# Altium schematic sheet USB_INPUT.SchDoc
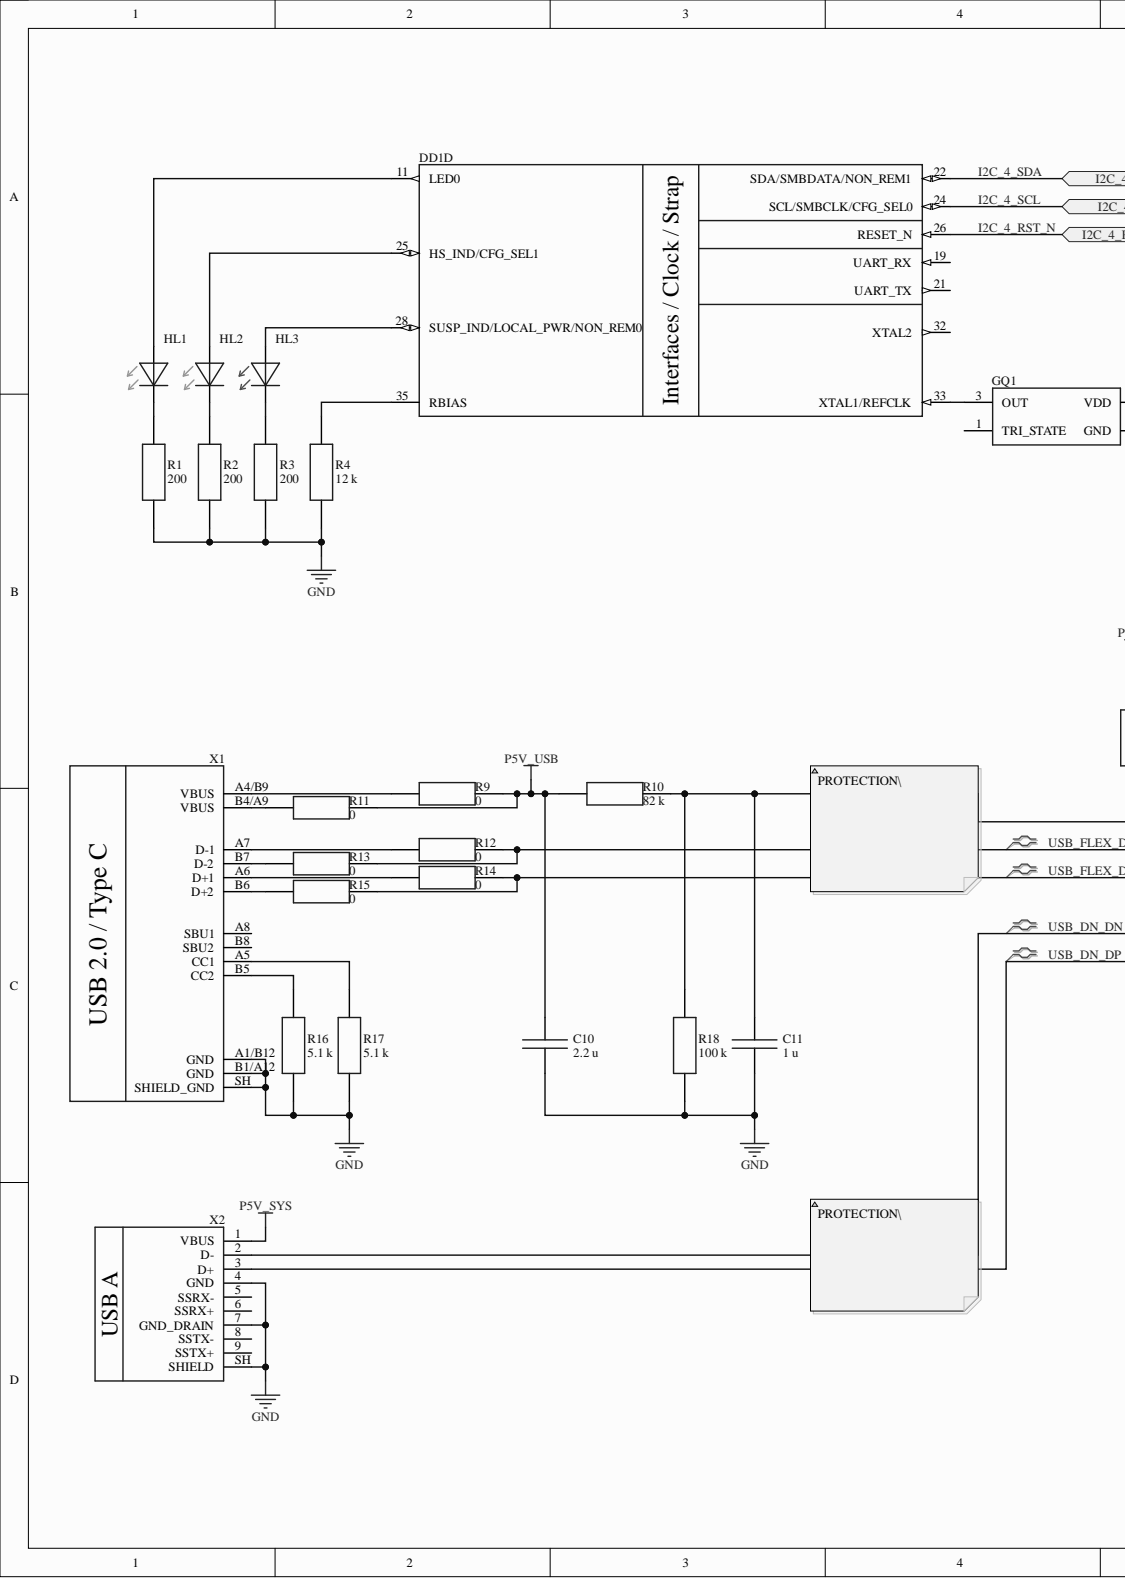
[diagram p0: USB_INPUT.SchDoc, left]
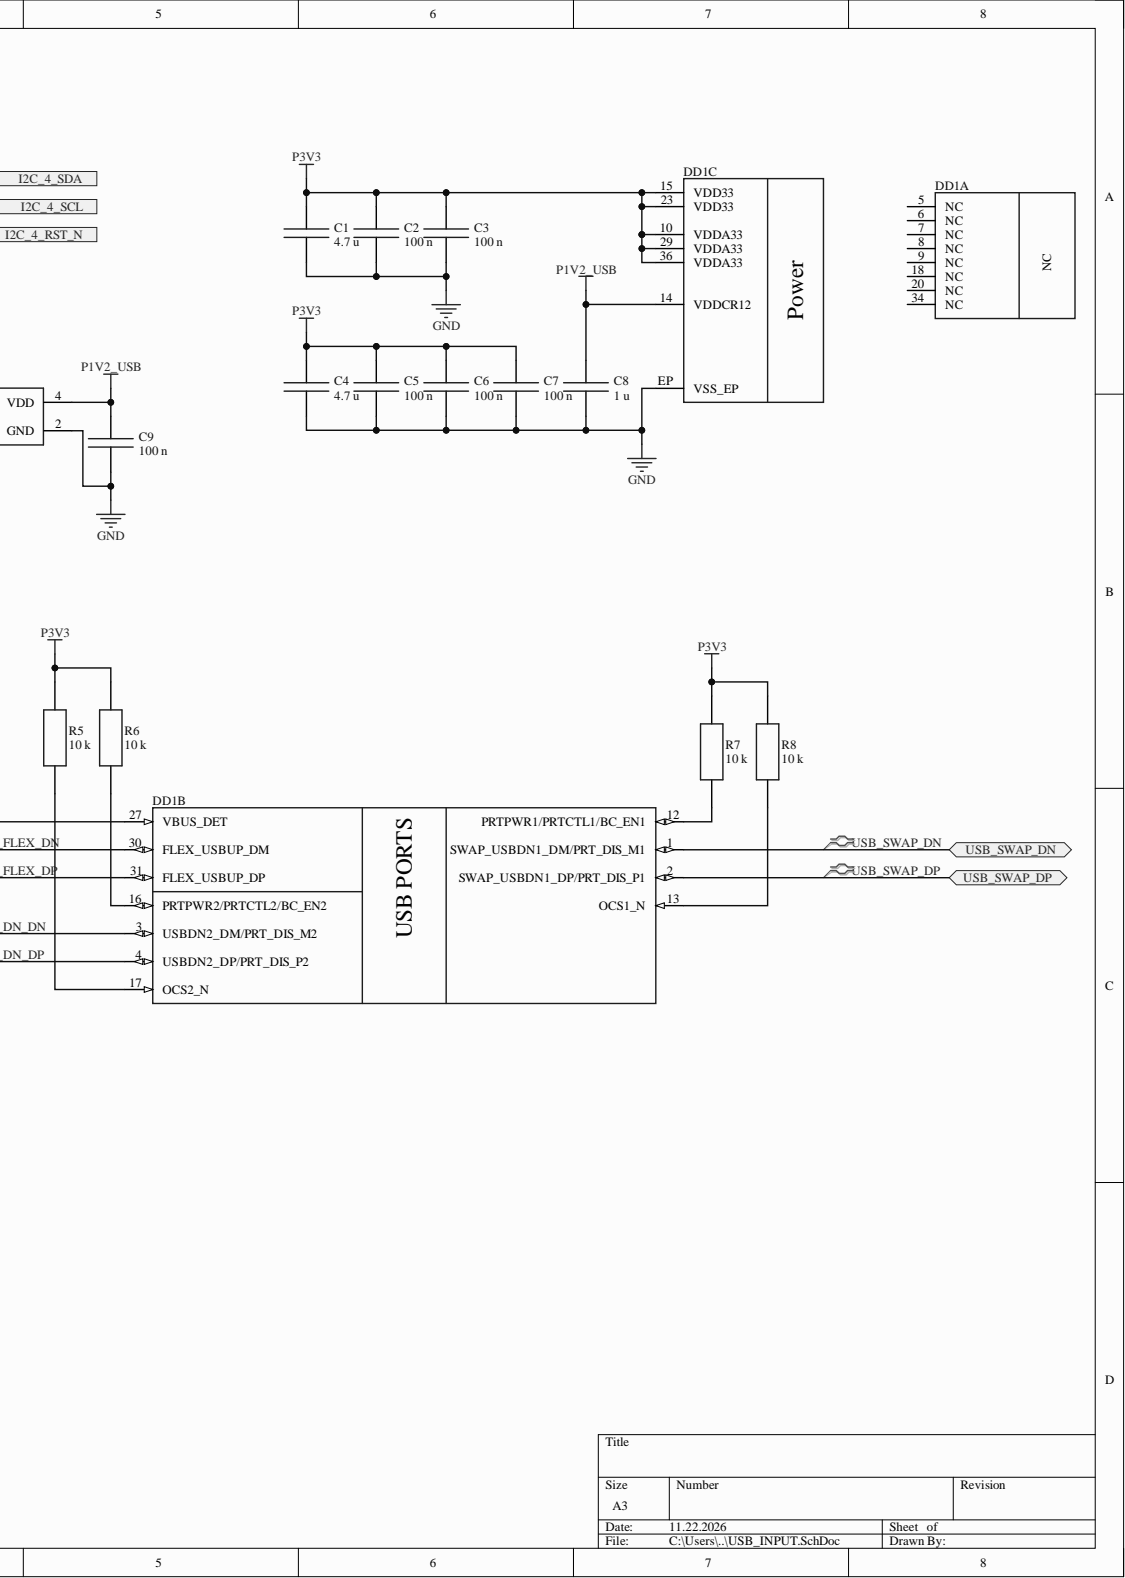
[diagram p1: USB_INPUT.SchDoc, right]

## Parts
C1  4.7 u  [C0402]
C2  100 n  [C0402]
C3  100 n  [C0402]
C4  4.7 u  [C0402]
C5  100 n  [C0402]
C6  100 n  [C0402]
C7  100 n  [C0402]
C8  1 u  [C0402]
C9  100 n  [C0402]
C10  2.2 u  [C0402]
C11  1 u  [C0402]
DD1  USB2532  [SQFN-36_6X6_P0.5_EP3.7X3.7]  (value not drawn)  (parts A,B,C,D)
GQ1  24 MHz  [GQ_SMD1612-4P]  (value not drawn)
HL1  APT1608CGCK  [LED1608]  (value not drawn)
HL2  APT1608CGCK  [LED1608]  (value not drawn)
HL3  APT1608SURCK  [LED1608]  (value not drawn)
R1  200  [R0805]
R2  200  [R0805]
R3  200  [R0805]
R4  12 k  [R0402]
R5  10 k  [R0402]
R6  10 k  [R0402]
R7  10 k  [R0402]
R8  10 k  [R0402]
R9  0  [R0402]
R10  82 k  [R0402]
R11  0  [R0402]
R12  0  [R0402]
R13  0  [R0402]
R14  0  [R0402]
R15  0  [R0402]
R16  5.1 k  [R0402]
R17  5.1 k  [R0402]
R18  100 k  [R0402]
X1  USB4105-GF-A  [USB4105-GF-A]  (value not drawn)
X2  484080003  [484080003]  (value not drawn)

## Ports
I2C_4_RST_N, I2C_4_SCL, I2C_4_SDA, USB_SWAP_DN, USB_SWAP_DP

## Nets
GND: C1.2 C2.2 C3.2 C4.2 C5.2 C6.2 C7.2 C8.2 C9.2 C10.2 C11.2 DD1.EP(VSS_EP) GQ1.2(GND) R1.1 R2.1 R3.1 R4.1 R16.1 R17.1 R18.1 X1.A1/B12(GND) X1.B1/A12(GND) X1.SH(SHIELD_GND) X2.4(GND) X2.7(GND_DRAIN) X2.SH(SHIELD)
P1V2_USB: C8.1 C9.1 DD1.14(VDDCR12) GQ1.4(VDD)
P3V3: C1.1 C2.1 C3.1 C4.1 C5.1 C6.1 C7.1 DD1.10(VDDA33) DD1.15(VDD33) DD1.23(VDD33) DD1.29(VDDA33) DD1.36(VDDA33) R5.2 R6.2 R7.2 R8.2
P5V_SYS: X2.1(VBUS)
P5V_USB: C10.1 R9.2 R10.1 R11.2
I2C_4_RST_N: DD1.26(RESET_N) ; port I2C_4_RST_N
I2C_4_SCL: DD1.24(SCL/SMBCLK/CFG_SEL0) ; port I2C_4_SCL
I2C_4_SDA: DD1.22(SDA/SMBDATA/NON_REM1) ; port I2C_4_SDA
USB_DN_DN: DD1.3(USBDN2_DM/PRT_DIS_M2) X2.2(D-)
USB_DN_DP: DD1.4(USBDN2_DP/PRT_DIS_P2) X2.3(D+)
USB_FLEX_DN: DD1.30(FLEX_USBUP_DM) R12.2 R13.2
USB_FLEX_DP: DD1.31(FLEX_USBUP_DP) R14.2 R15.2
USB_SWAP_DN: DD1.1(SWAP_USBDN1_DM/PRT_DIS_M1) ; port USB_SWAP_DN
USB_SWAP_DP: DD1.2(SWAP_USBDN1_DP/PRT_DIS_P1) ; port USB_SWAP_DP
NetC11_1: C11.1 DD1.27(VBUS_DET) R10.2 R18.2
NetDD1_11: DD1.11(LED0) HL1.2
NetDD1_12: DD1.12(PRTPWR1/PRTCTL1/BC_EN1) R7.1
NetDD1_13: DD1.13(OCS1_N) R8.1
NetDD1_16: DD1.16(PRTPWR2/PRTCTL2/BC_EN2) R6.1
NetDD1_17: DD1.17(OCS2_N) R5.1
NetDD1_25: DD1.25(HS_IND/CFG_SEL1) HL2.2
NetDD1_28: DD1.28(SUSP_IND/LOCAL_PWR/NON_REM0) HL3.2
NetDD1_33: DD1.33(XTAL1/REFCLK) GQ1.3(OUT)
NetDD1_35: DD1.35(RBIAS) R4.2
NetHL1_1: HL1.1 R1.2
NetHL2_1: HL2.1 R2.2
NetHL3_1: HL3.1 R3.2
NetR9_1: R9.1 X1.A4/B9(VBUS)
NetR11_1: R11.1 X1.B4/A9(VBUS)
NetR12_1: R12.1 X1.A7(D-1)
NetR13_1: R13.1 X1.B7(D-2)
NetR14_1: R14.1 X1.A6(D+1)
NetR15_1: R15.1 X1.B6(D+2)
NetR16_2: R16.2 X1.B5(CC2)
NetR17_2: R17.2 X1.A5(CC1)

Not connected: DD1 5(NC) 6(NC) 7(NC) 8(NC) 9(NC) 18(NC) 19(UART_RX) 20(NC) 21(UART_TX) 32(XTAL2) 34(NC); GQ1 1(TRI_STATE); X1 A8(SBU1) B8(SBU2); X2 5(SSRX-) 6(SSRX+) 8(SSTX-) 9(SSTX+)

## Library data of the parts
C1 C4 = C0402 X7R 4.7 uF 10% 25 V: MLCC 0402, X7R, 4.7 uF, 10%, 16 V; Capacitance: 4.7 uF; Database Table Name: C_Capacitor; ID: C_001115; Voltage Rating: 16 V; Component Kind: Standard; Dielectric: X7R; Library Name: Database_Libs1.DbLib; Mounting Technology: SMD; Package: C0402; Part Number: C0402 X7R 4.7 uF 10% 25 V; Temperature Range: -55...+125 C; Tolerance: 10%
C2 C3 C5-C7 C9 = C0402 X7R 100 nF 10% 16 V: MLCC 0402, X7R, 100 nF, 10%, 16 V; Capacitance: 100 nF; Database Table Name: C_Capacitor; ID: C_000136; Voltage Rating: 16 V; Component Kind: Standard; Dielectric: X7R; Library Name: Database_Libs1.DbLib; Mounting Technology: SMD; Package: C0402; Part Number: C0402 X7R 100 nF 10% 16 V; Temperature Range: -55...+125 C; Tolerance: 10%
C8 C11 = C0402 X7R 1 uF 10% 16 V: MLCC 0402, X7R, 1 uF, 10%, 16 V; Capacitance: 1 uF; Database Table Name: C_Capacitor; ID: C_000148; Voltage Rating: 16 V; Component Kind: Standard; Dielectric: X7R; Library Name: Database_Libs1.DbLib; Mounting Technology: SMD; Package: C0402; Part Number: C0402 X7R 1 uF 10% 16 V; Temperature Range: -55...+125 C; Tolerance: 10%
C10 = C0402 X7R 2.2 uF 10% 16 V: MLCC 0402, X7R, 2.2 uF, 10%, 16 V; Capacitance: 2.2 uF; Database Table Name: C_Capacitor; ID: C_001116; Voltage Rating: 16 V; Component Kind: Standard; Dielectric: X7R; Library Name: Database_Libs1.DbLib; Mounting Technology: SMD; Package: C0402; Part Number: C0402 X7R 2.2 uF 10% 16 V; Temperature Range: -55...+125 C; Tolerance: 10%
DD1 = USB2532: IC, USB HUB CONTROLLER, USB2532, USB 2.0 HI-SPEED 2-PORT, I2C/SMBUS, SQFN36, 36P, P0.5mm; Manufacturer: Microchip; Channel Count: 2; Data Rate: 480 Mbit/s; Database Table Name: IC_Interface; Interface Type: USB 2.0; Component Kind: Standard; ComponentLink1Description: Manufacturer documentation; ComponentLink1URL: https://ww1.microchip.com/downloads/aemDocuments/documents/UNG/ProductDocuments/DataSheets/USB2532-Data-Sheet-DS00001701.pdf; Library Name: Database_Libs1.DbLib; Mounting Technology: SMT; Package: SQFN36; Part Number: USB2532
GQ1 = ASCO7-24.000MHZ-LR-T: OSC, XO, ASCO7-24.000MHZ-LR-T, 24 MHz, 1.2 V, CMOS, +/-25 ppm, 1.6x1.2 mm; Manufacturer: Abracon; Database Table Name: GQ_Frequency_Generator; ID: GQ_000002; Supply Voltage: 1.2 V; Component Kind: Standard; ComponentLink1Description: Manufacturer documentation; ComponentLink1URL: https://abracon.com/datasheets/ASCO7.pdf; Device Type: XO; Frequency: 24 MHz; Library Name: Database_Libs1.DbLib; Manufacturer Part Number: ASCO7-24.000MHZ-LR-T; Mounting Technology: SMD; Output Type: CMOS; Package: 1.6x1.2 mm; Part Number: ASCO7-24.000MHZ-LR-T
HL1 HL2 = APT1608CGCK: LED, INDICATOR, APT1608CGCK, GREEN, 570 nm, 0603; Manufacturer: Kingbright; Database Table Name: OPTO_Optoelectronics; Forward Current: 20 mA (test); Forward Voltage: 2.1 V typ @ 20 mA; ID: OPTO_000002; Luminous Intensity: 50 mcd typ @ 20 mA; Optoelectronic Type: LED, GREEN; Wavelength: 570 nm dominant; Component Kind: Standard; ComponentLink1Description: Manufacturer datasheet; ComponentLink1URL: https://www.kingbrightusa.com/images/catalog/SPEC/APT1608CGCK.pdf; Library Name: Database_Libs1.DbLib; Manufacturer Part Number: APT1608CGCK; Mounting Technology: SMD; Package: 0603 (1608 Metric); Part Number: APT1608CGCK
HL3 = APT1608SURCK: LED, INDICATOR, APT1608SURCK, RED, 630 nm, 0603; Manufacturer: Kingbright; Database Table Name: OPTO_Optoelectronics; Forward Current: 20 mA (test); Forward Voltage: 1.95 V typ @ 20 mA; ID: OPTO_000001; Luminous Intensity: 80 mcd typ @ 20 mA; Optoelectronic Type: LED, RED; Wavelength: 630 nm dominant; Component Kind: Standard; ComponentLink1Description: Manufacturer datasheet; ComponentLink1URL: https://www.kingbrightusa.com/images/catalog/SPEC/APT1608SURCK.pdf; Library Name: Database_Libs1.DbLib; Manufacturer Part Number: APT1608SURCK; Mounting Technology: SMD; Package: 0603 (1608 Metric); Part Number: APT1608SURCK
R1-R3 = R0805 200 Ohm 1%: SMD resistor 0805, 200 Ohm, 1%; Database Table Name: R_Resistor; ID: R_000394; Resistance: 200 Ohm; Component Kind: Standard; Library Name: Database_Libs1.DbLib; Mounting Technology: SMD; Package: R0805; Part Number: R0805 200 Ohm 1%; Technology: Thick Film; Tolerance: 1%
R4 = R0402 12 kOhm 1%: SMD resistor 0402, 12 kOhm, 1%; Database Table Name: R_Resistor; ID: R_000099; Resistance: 12 kOhm; Component Kind: Standard; Library Name: Database_Libs1.DbLib; Mounting Technology: SMD; Package: R0402; Part Number: R0402 12 kOhm 1%; Technology: Thick Film; Tolerance: 1%
R5-R8 = R0402 10 kOhm 1%: SMD resistor 0402, 10 kOhm, 1%; Database Table Name: R_Resistor; ID: R_000097; Resistance: 10 kOhm; Component Kind: Standard; Library Name: Database_Libs1.DbLib; Mounting Technology: SMD; Package: R0402; Part Number: R0402 10 kOhm 1%; Technology: Thick Film; Tolerance: 1%
R9 R11-R15 = R0402 0 Ohm 1%: SMD resistor 0402, 0 Ohm, 1%; Database Table Name: R_Resistor; ID: R_000677; Component Kind: Standard; Library Name: Database_Libs1.DbLib; Mounting Technology: SMD; Package: R0402; Part Number: R0402 0 Ohm 1%; Resistance: 0; Technology: Thick Film; Tolerance: 1%
R10 = R0402 82 kOhm 1%: SMD resistor 0402, 82 kOhm, 1%; Database Table Name: R_Resistor; ID: R_000119; Resistance: 82 kOhm; Component Kind: Standard; Library Name: Database_Libs1.DbLib; Mounting Technology: SMD; Package: R0402; Part Number: R0402 82 kOhm 1%; Technology: Thick Film; Tolerance: 1%
R16 R17 = R0402 5.1 kOhm 1%: SMD resistor 0402, 5.1 kOhm, 1%; Database Table Name: R_Resistor; ID: R_000090; Component Kind: Standard; Library Name: Database_Libs1.DbLib; Mounting Technology: SMD; Package: R0402; Part Number: R0402 5.1 kOhm 1%; Resistance: 5.1 kOhm; Technology: Thick Film; Tolerance: 1%
R18 = R0402 100 kOhm 1%: SMD resistor 0402, 100 kOhm, 1%; Database Table Name: R_Resistor; ID: R_000121; Component Kind: Standard; Library Name: Database_Libs1.DbLib; Mounting Technology: SMD; Package: R0402; Part Number: R0402 100 kOhm 1%; Resistance: 100 kOhm; Technology: Thick Film; Tolerance: 1%
X1 = USB4105-GF-A: CONN, USB2.0 TYPE-C, USB4105-GF-A, 16P, P0.5, FEMALE, RA, SMT/THT; Manufacturer: GCT; Database Table Name: X_Connector; ID: X_000005; Component Kind: Standard; ComponentLink1Description: Manufacturer datasheet / drawing; ComponentLink1URL: https://gct.co/files/drawings/usb4105.pdf; Library Name: Database_Libs1.DbLib; Manufacturer Part Number: USB4105-GF-A; Mounting Technology: SMT/THT; Package: USB-C 16 contacts; 0.95 mm shell stakes; Part Number: USB4105-GF-A
X2 = 484080003: CONN, USB 3.0 TYPE A, 484080003, 9P, RECEPTACLE, VERTICAL, BLUE, THT; Manufacturer: Molex; Connector Type: USB 3.0 Type A, vertical; Contact Count: 9; Current Rating: 1.8 A max; Database Table Name: X_Connector; ID: X_000009; Pitch: 2.00 / 2.50 mm; Row Count: 2; Voltage Rating: 30 V AC/DC; Component Kind: Standard; ComponentLink1Description: Manufacturer documentation; ComponentLink1URL: https://www.molex.com/content/dam/molex/molex-dot-com/products/automated/en-us/salesdrawingpdf/484/48408/484080003_sd.pdf; Gender: Receptacle; Library Name: Database_Libs1.DbLib; Manufacturer Part Number: 484080003; Mounting Technology: THT; Package: Vertical, through hole; Part Number: 484080003
